# Altium schematic sheet overview.SchDoc
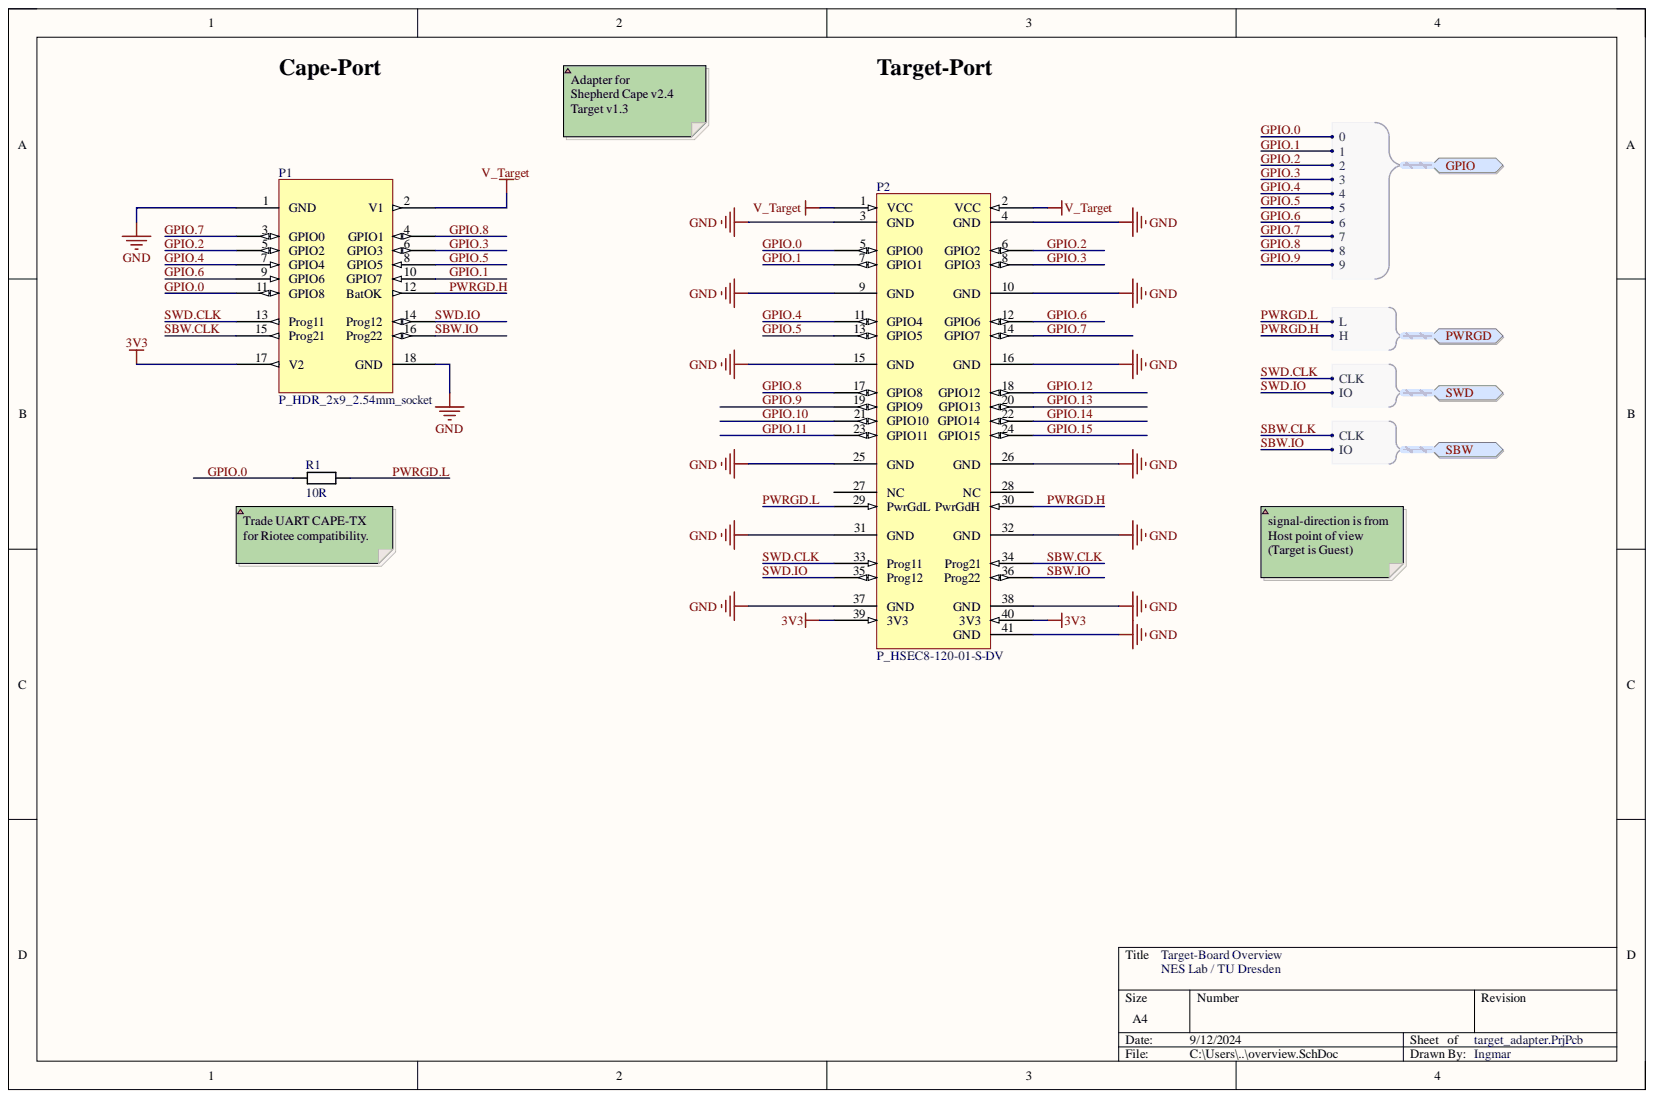
[diagram p0: overview.SchDoc]

## Parts
P1  P_HDR_2x9_2.54mm_socket  [P_Pinheader_2x9_R2.54mm_pressfit]
P2  P_HSEC8-120-01-S-DV  [P_HSEC8-120-01-S-DV]
R1  value 10R  [RESC1005X04]  (hidden comment: R_0402_10R)

## Ports
GPIO, GPIO.0, GPIO.1, GPIO.2, GPIO.3, GPIO.4, GPIO.5, GPIO.6, GPIO.7, GPIO.8, GPIO.9, PWRGD, PWRGD.H, PWRGD.L, SBW, SBW.CLK, SBW.IO, SWD, SWD.CLK, SWD.IO

## Nets
3V3: P1.17(V2) P2.39(3V3) P2.40(3V3)
GND: P1.1(GND) P1.18(GND) P2.3(GND) P2.4(GND) P2.9(GND) P2.10(GND) P2.15(GND) P2.16(GND) P2.25(GND) P2.26(GND) P2.31(GND) P2.32(GND) P2.37(GND) P2.38(GND) P2.41(GND)
V_Target: P1.2(V1) P2.1(VCC) P2.2(VCC)
GPIO.0: P1.11(GPIO8) P2.5(GPIO0) R1.1 ; port GPIO
GPIO.1: P1.10(GPIO7) P2.7(GPIO1) P2.21(GPIO10) ; port GPIO  [also labelled GPIO.10]
GPIO.2: P1.5(GPIO2) P2.6(GPIO2) ; port GPIO
GPIO.3: P1.6(GPIO3) P2.8(GPIO3) ; port GPIO
GPIO.4: P1.7(GPIO4) P2.11(GPIO4) ; port GPIO
GPIO.5: P1.8(GPIO5) P2.13(GPIO5) ; port GPIO
GPIO.6: P1.9(GPIO6) P2.12(GPIO6) ; port GPIO
GPIO.7: P1.3(GPIO0) P2.14(GPIO7) ; port GPIO
GPIO.8: P1.4(GPIO1) P2.17(GPIO8) ; port GPIO
GPIO.9: P2.19(GPIO9) ; port GPIO
GPIO.11: P2.23(GPIO11)
GPIO.12: P2.18(GPIO12)
GPIO.13: P2.20(GPIO13)
GPIO.14: P2.22(GPIO14)
GPIO.15: P2.24(GPIO15)
PWRGD.H: P1.12(BatOK) P2.30(PwrGdH) ; port PWRGD
PWRGD.L: P2.29(PwrGdL) R1.2 ; port PWRGD
SBW.CLK: P1.15(Prog21) P2.34(Prog21) ; port SBW
SBW.IO: P1.16(Prog22) P2.36(Prog22) ; port SBW
SWD.CLK: P1.13(Prog11) P2.33(Prog11) ; port SWD
SWD.IO: P1.14(Prog12) P2.35(Prog12) ; port SWD

Not connected: P2 27(NC) 28(NC)

## Library data of the parts
P1 = .P_HDR_2x9_2.54mm_female: Gold, Angled; Manufacturer: Samtech; Manufacturer PN: SSQ-109-02-S-D-RA; I_max: 6.3; Component Kind: Standard; Distributer: Mouser; Distributer PN: 200-SSQ10902SDRA; Price n10 [€]: 4,24; Library Name: shepherd_parts.SchLib
P2 = .P_HSEC8-120-01-S-DV: Gold, Vertical, EdgeConnector, 2 Row, .8mm distance; Manufacturer: Samtec; Manufacturer PN: HSEC8-120-01-L-DV-A-K-TR; I_max: 2.8A; V_max: 240V; Component Kind: Standard; Distributer: Mouser; Distributer PN: 200-HSEC812001LDVAKT; Price n10 [€]: 3.17; Library Name: shepherd_parts.SchLib
R1 = .R_0402_10R: Resistor; Manufacturer: Panasonic; Manufacturer PN: ERJ-2GEJ100X; P_max: 100mW; Tolerance: 5%; V_max: 50V; Component Kind: Standard; Distributer: Mouser; Distributer PN: 667-ERJ-2GEJ100X; Price n10 [€]: 0.02; Library Name: shepherd_parts.SchLib
